# Altium schematic sheet hybris.SchDoc
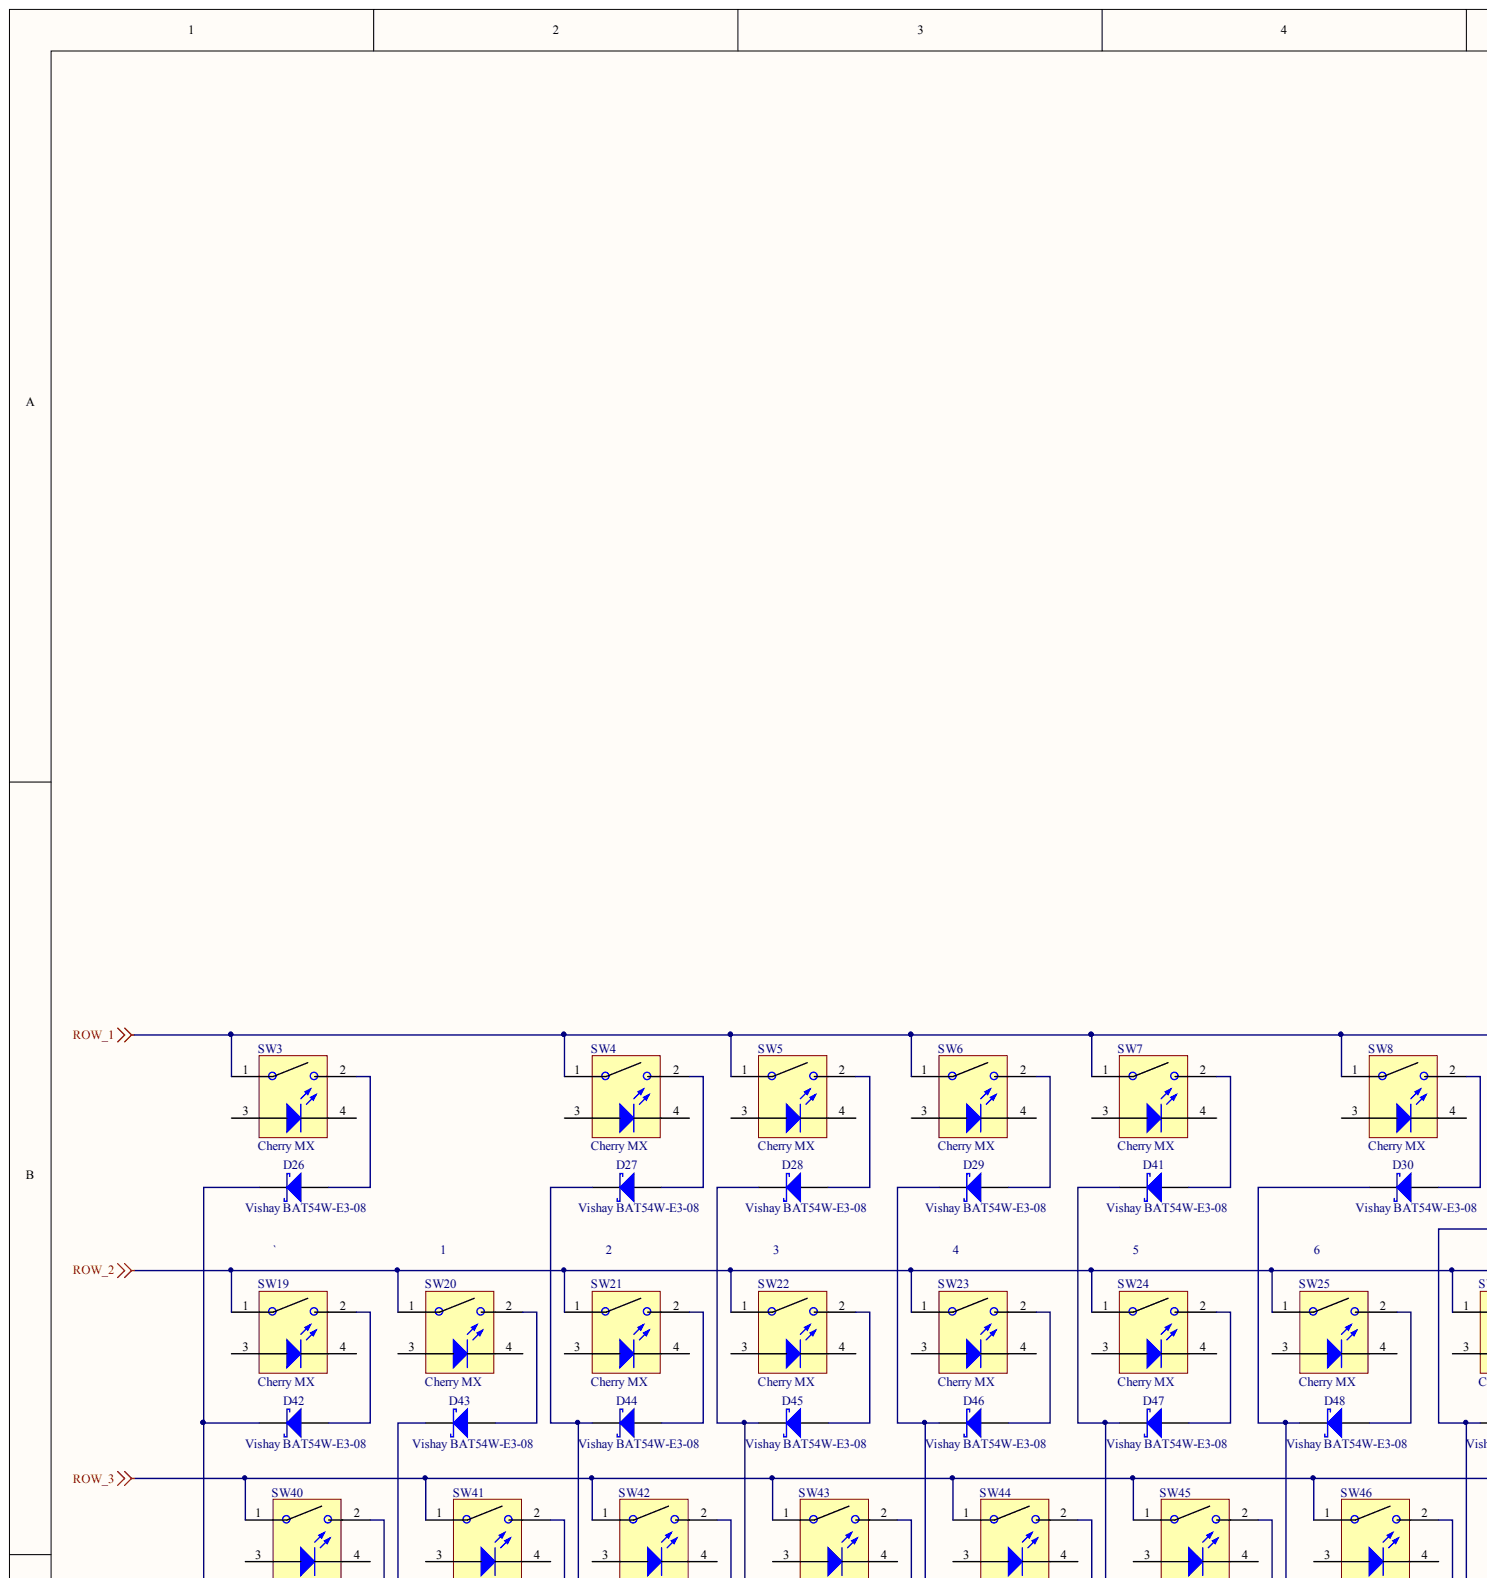
[diagram p0: hybris.SchDoc, top left]
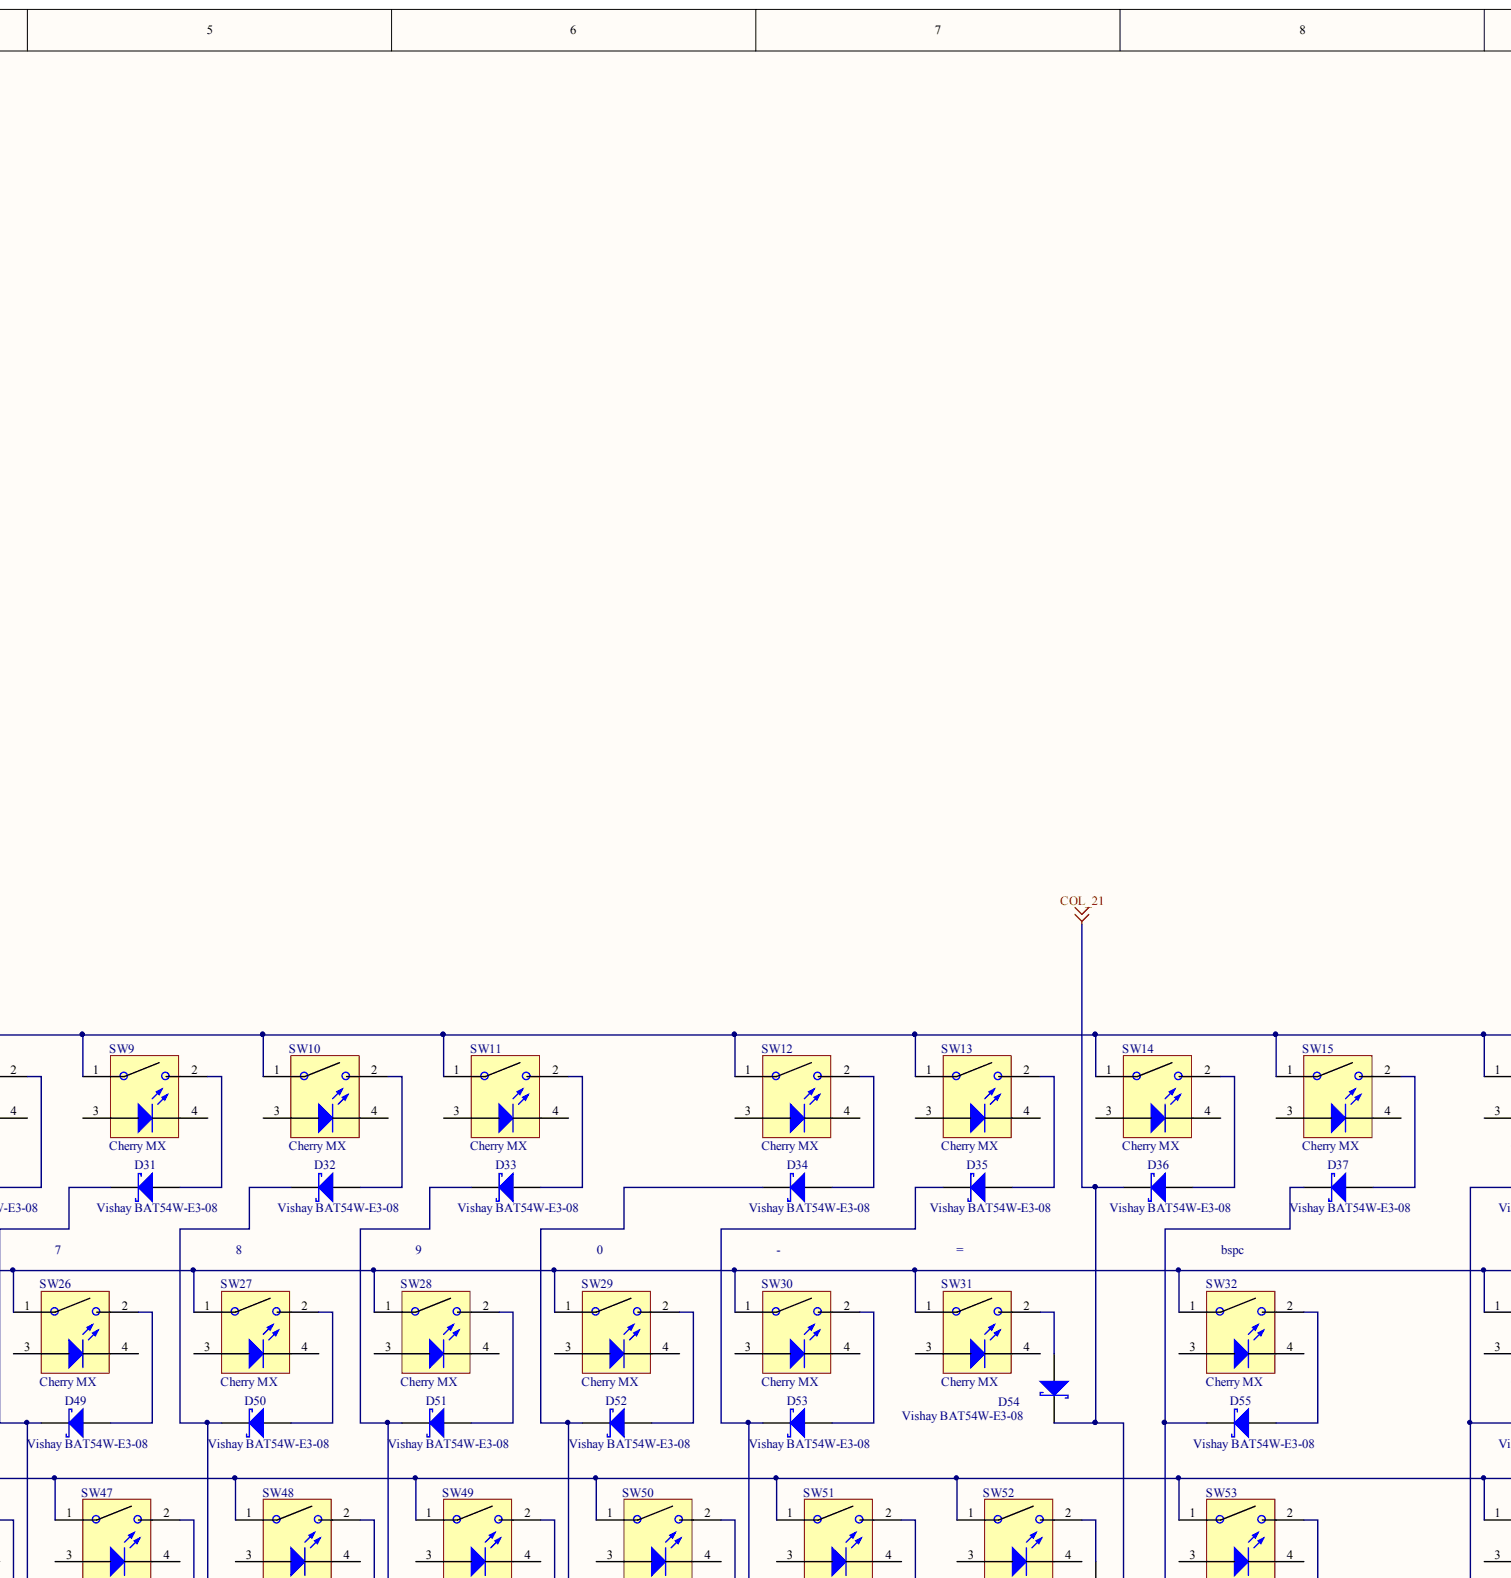
[diagram p1: hybris.SchDoc, top centre]
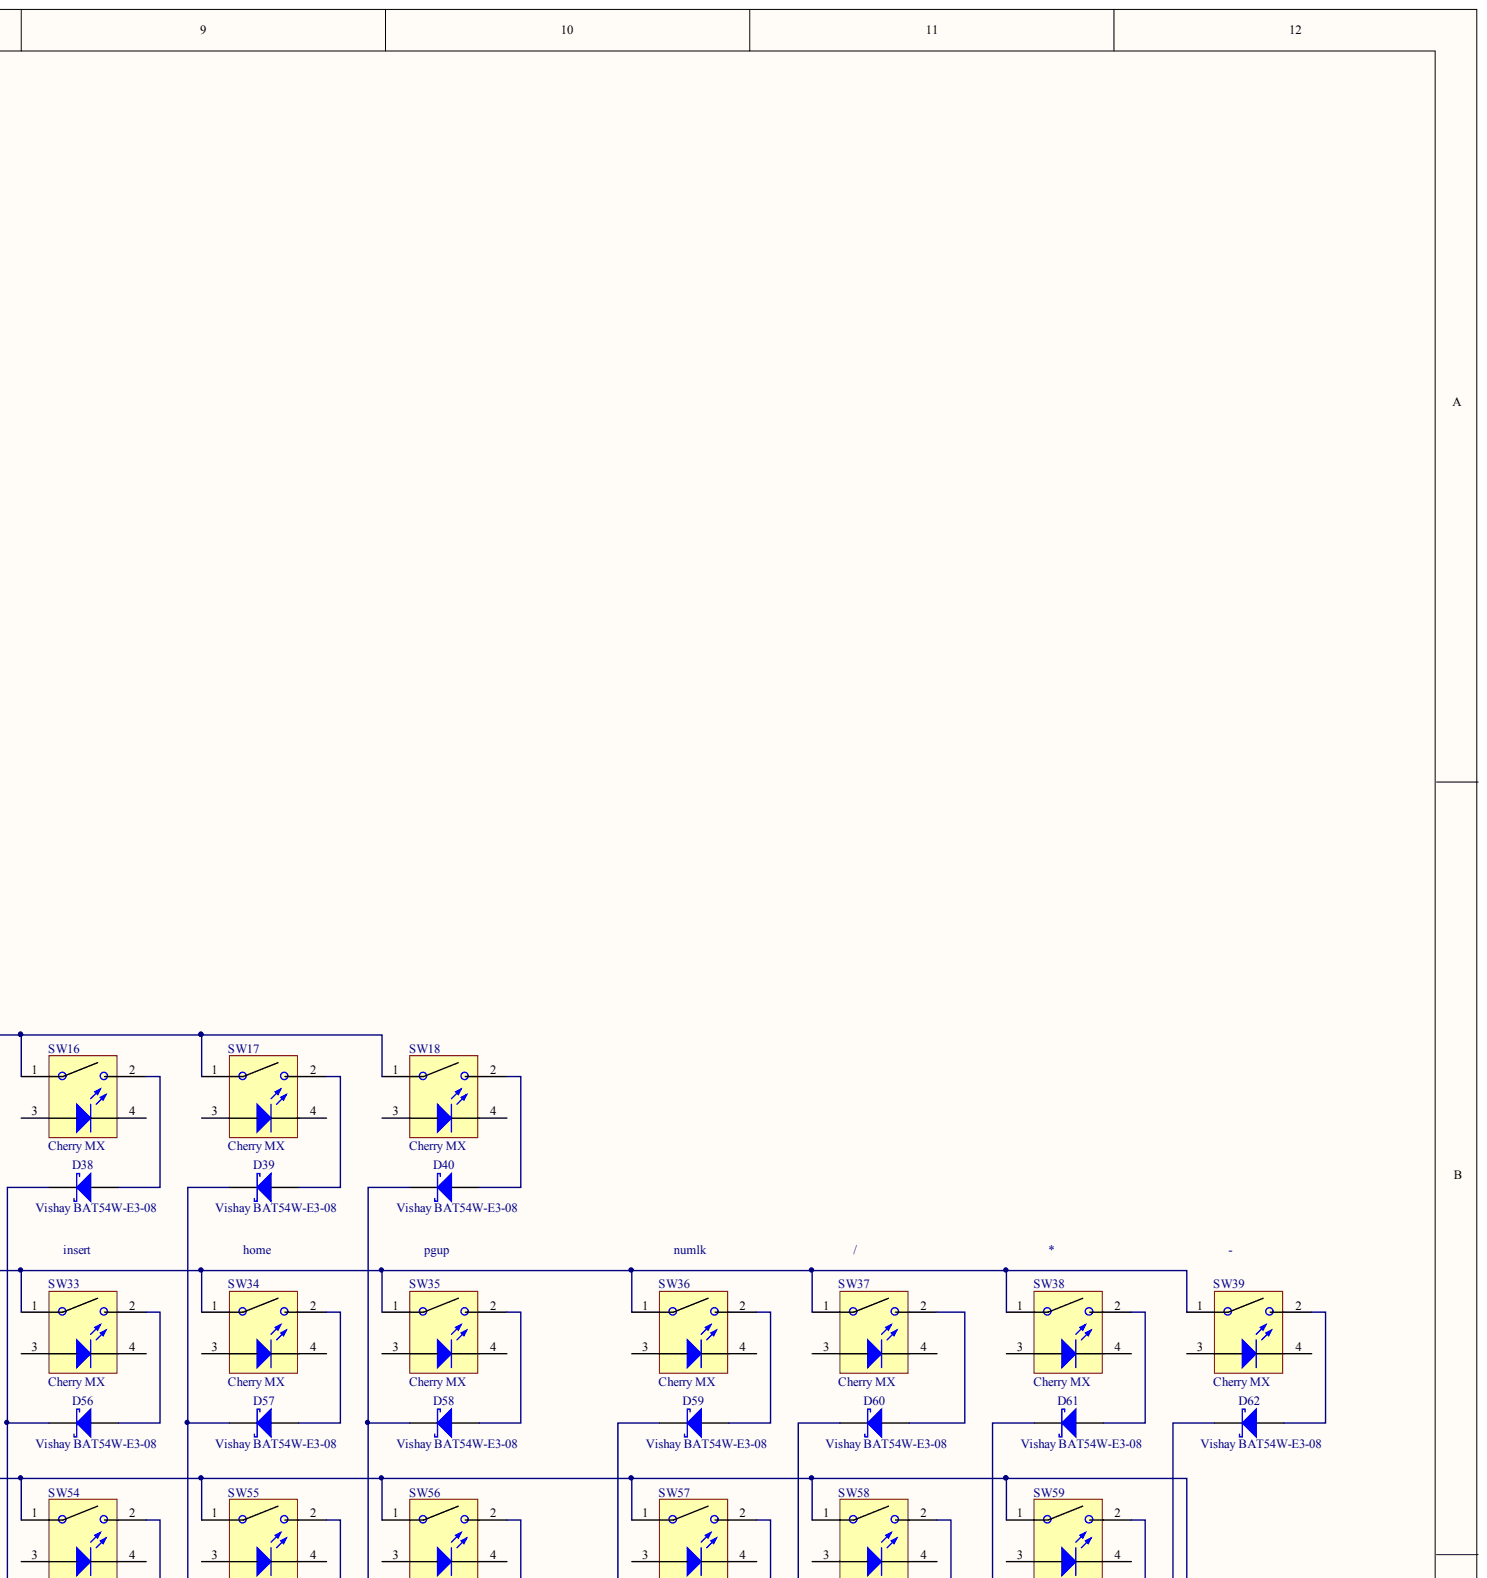
[diagram p2: hybris.SchDoc, top right]
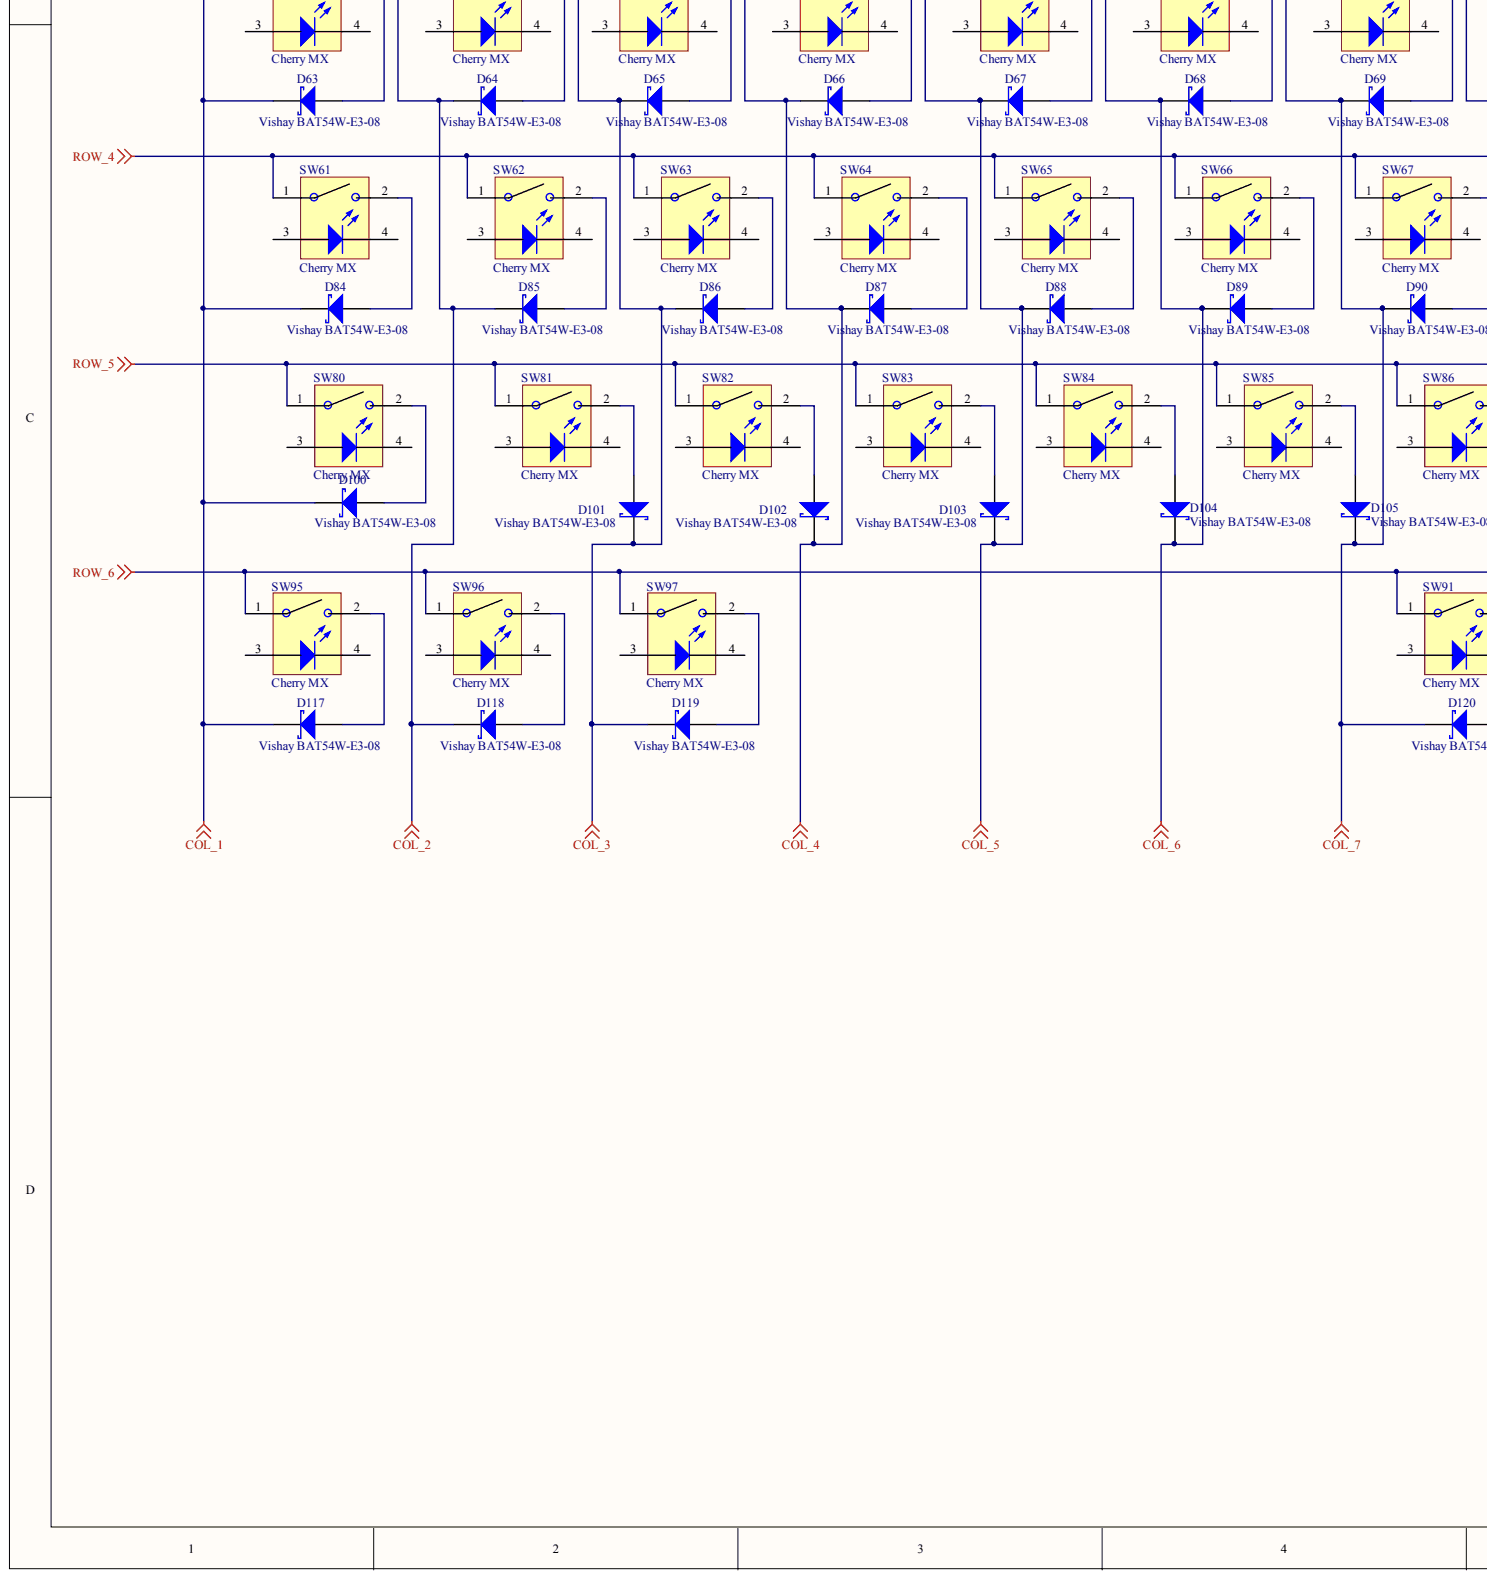
[diagram p3: hybris.SchDoc, bottom left]
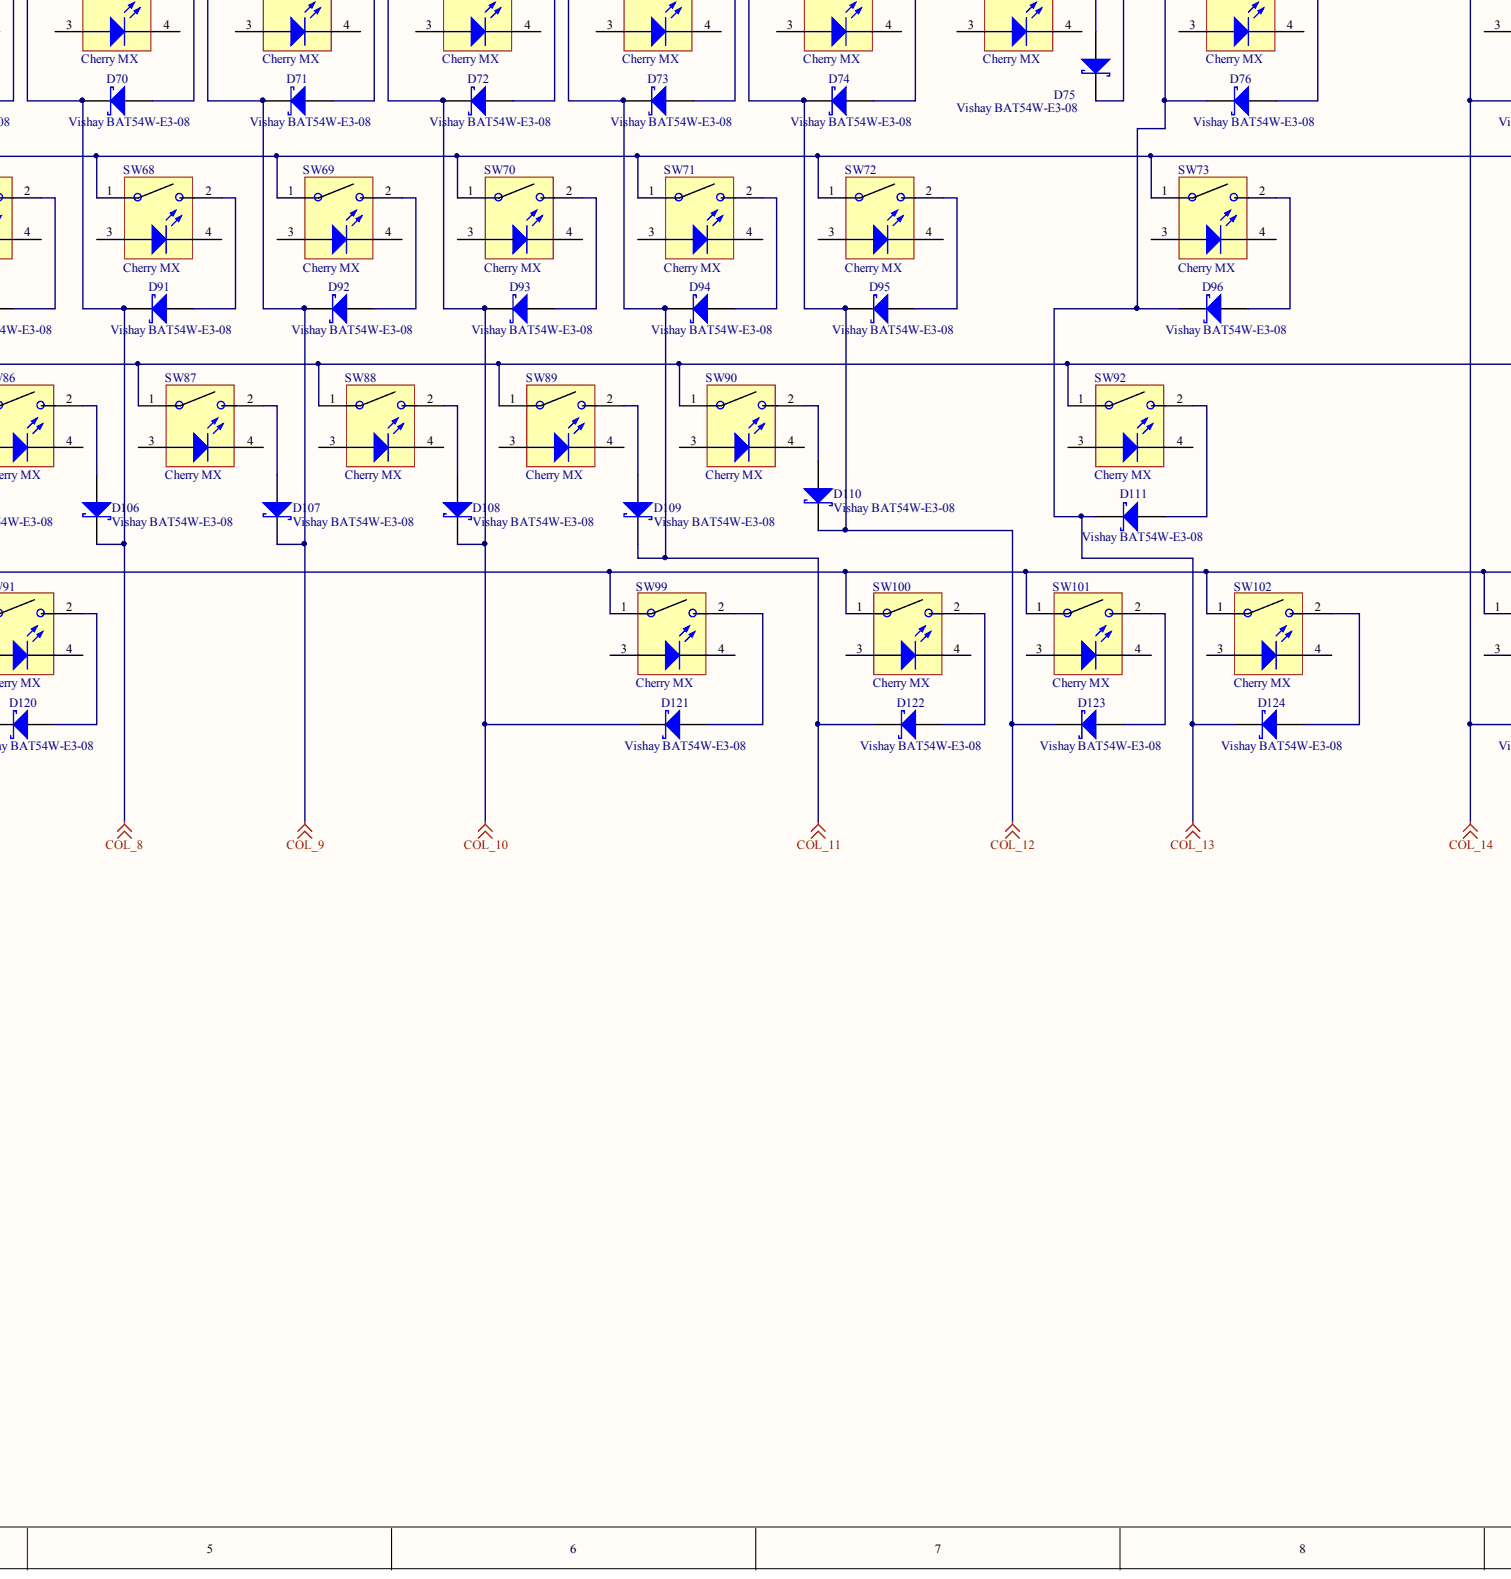
[diagram p4: hybris.SchDoc, bottom centre]
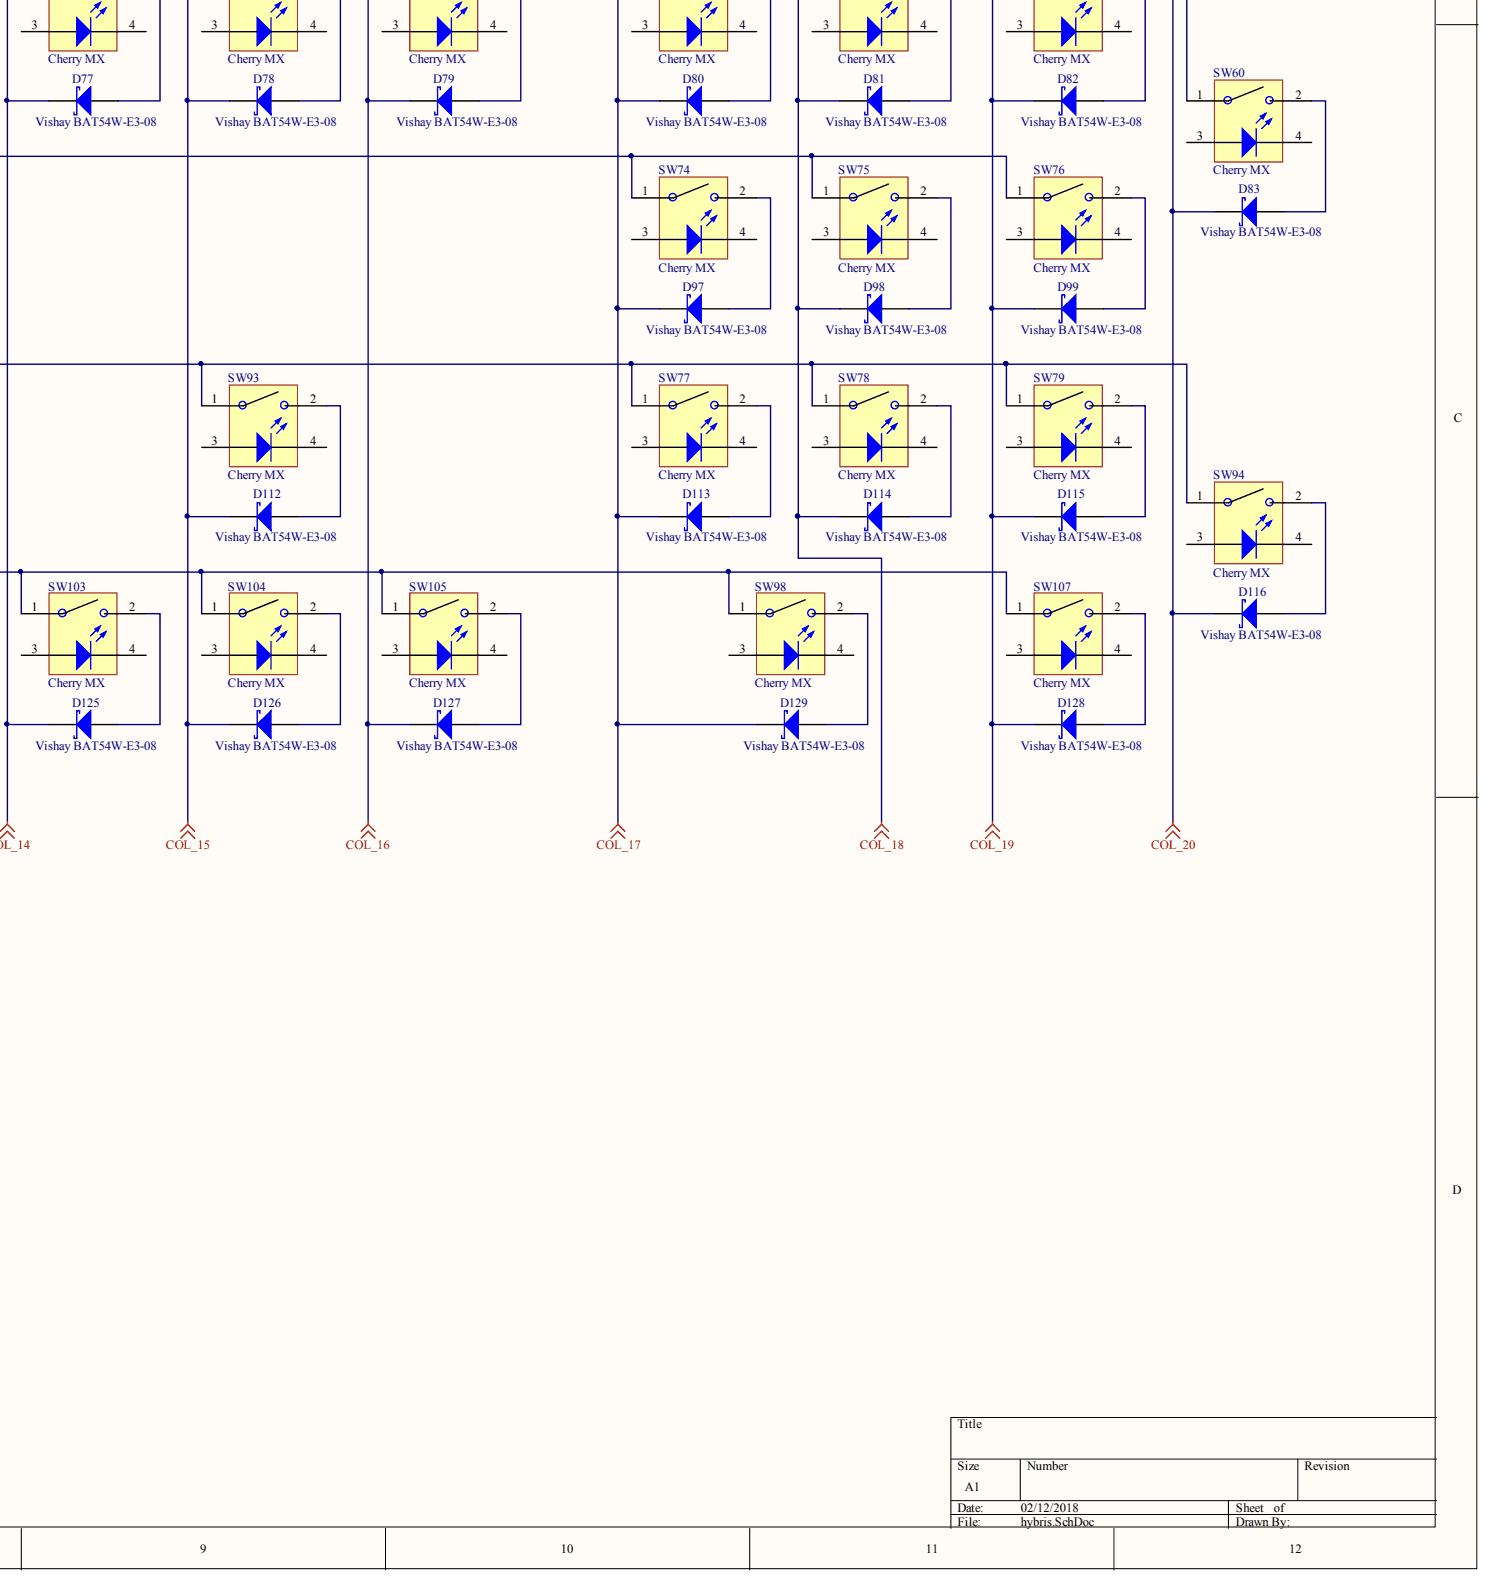
[diagram p5: hybris.SchDoc, bottom right]

## Parts
D26  Vishay BAT54W-E3-08  [PCB-12559945-1]
D27  Vishay BAT54W-E3-08  [PCB-12559945-1]
D28  Vishay BAT54W-E3-08  [PCB-12559945-1]
D29  Vishay BAT54W-E3-08  [PCB-12559945-1]
D30  Vishay BAT54W-E3-08  [PCB-12559945-1]
D31  Vishay BAT54W-E3-08  [PCB-12559945-1]
D32  Vishay BAT54W-E3-08  [PCB-12559945-1]
D33  Vishay BAT54W-E3-08  [PCB-12559945-1]
D34  Vishay BAT54W-E3-08  [PCB-12559945-1]
D35  Vishay BAT54W-E3-08  [PCB-12559945-1]
D36  Vishay BAT54W-E3-08  [PCB-12559945-1]
D37  Vishay BAT54W-E3-08  [PCB-12559945-1]
D38  Vishay BAT54W-E3-08  [PCB-12559945-1]
D39  Vishay BAT54W-E3-08  [PCB-12559945-1]
D40  Vishay BAT54W-E3-08  [PCB-12559945-1]
D41  Vishay BAT54W-E3-08  [PCB-12559945-1]
D42  Vishay BAT54W-E3-08  [PCB-12559945-1]
D43  Vishay BAT54W-E3-08  [PCB-12559945-1]
D44  Vishay BAT54W-E3-08  [PCB-12559945-1]
D45  Vishay BAT54W-E3-08  [PCB-12559945-1]
D46  Vishay BAT54W-E3-08  [PCB-12559945-1]
D47  Vishay BAT54W-E3-08  [PCB-12559945-1]
D48  Vishay BAT54W-E3-08  [PCB-12559945-1]
D49  Vishay BAT54W-E3-08  [PCB-12559945-1]
D50  Vishay BAT54W-E3-08  [PCB-12559945-1]
D51  Vishay BAT54W-E3-08  [PCB-12559945-1]
D52  Vishay BAT54W-E3-08  [PCB-12559945-1]
D53  Vishay BAT54W-E3-08  [PCB-12559945-1]
D54  Vishay BAT54W-E3-08  [PCB-12559945-1]
D55  Vishay BAT54W-E3-08  [PCB-12559945-1]
D56  Vishay BAT54W-E3-08  [PCB-12559945-1]
D57  Vishay BAT54W-E3-08  [PCB-12559945-1]
D58  Vishay BAT54W-E3-08  [PCB-12559945-1]
D59  Vishay BAT54W-E3-08  [PCB-12559945-1]
D60  Vishay BAT54W-E3-08  [PCB-12559945-1]
D61  Vishay BAT54W-E3-08  [PCB-12559945-1]
D62  Vishay BAT54W-E3-08  [PCB-12559945-1]
D63  Vishay BAT54W-E3-08  [PCB-12559945-1]
D64  Vishay BAT54W-E3-08  [PCB-12559945-1]
D65  Vishay BAT54W-E3-08  [PCB-12559945-1]
D66  Vishay BAT54W-E3-08  [PCB-12559945-1]
D67  Vishay BAT54W-E3-08  [PCB-12559945-1]
D68  Vishay BAT54W-E3-08  [PCB-12559945-1]
D69  Vishay BAT54W-E3-08  [PCB-12559945-1]
D70  Vishay BAT54W-E3-08  [PCB-12559945-1]
D71  Vishay BAT54W-E3-08  [PCB-12559945-1]
D72  Vishay BAT54W-E3-08  [PCB-12559945-1]
D73  Vishay BAT54W-E3-08  [PCB-12559945-1]
D74  Vishay BAT54W-E3-08  [PCB-12559945-1]
D75  Vishay BAT54W-E3-08  [PCB-12559945-1]
D76  Vishay BAT54W-E3-08  [PCB-12559945-1]
D77  Vishay BAT54W-E3-08  [PCB-12559945-1]
D78  Vishay BAT54W-E3-08  [PCB-12559945-1]
D79  Vishay BAT54W-E3-08  [PCB-12559945-1]
D80  Vishay BAT54W-E3-08  [PCB-12559945-1]
D81  Vishay BAT54W-E3-08  [PCB-12559945-1]
D82  Vishay BAT54W-E3-08  [PCB-12559945-1]
D83  Vishay BAT54W-E3-08  [PCB-12559945-1]
D84  Vishay BAT54W-E3-08  [PCB-12559945-1]
D85  Vishay BAT54W-E3-08  [PCB-12559945-1]
D86  Vishay BAT54W-E3-08  [PCB-12559945-1]
D87  Vishay BAT54W-E3-08  [PCB-12559945-1]
D88  Vishay BAT54W-E3-08  [PCB-12559945-1]
D89  Vishay BAT54W-E3-08  [PCB-12559945-1]
D90  Vishay BAT54W-E3-08  [PCB-12559945-1]
D91  Vishay BAT54W-E3-08  [PCB-12559945-1]
D92  Vishay BAT54W-E3-08  [PCB-12559945-1]
D93  Vishay BAT54W-E3-08  [PCB-12559945-1]
D94  Vishay BAT54W-E3-08  [PCB-12559945-1]
D95  Vishay BAT54W-E3-08  [PCB-12559945-1]
D96  Vishay BAT54W-E3-08  [PCB-12559945-1]
D97  Vishay BAT54W-E3-08  [PCB-12559945-1]
D98  Vishay BAT54W-E3-08  [PCB-12559945-1]
D99  Vishay BAT54W-E3-08  [PCB-12559945-1]
D100  Vishay BAT54W-E3-08  [PCB-12559945-1]
D101  Vishay BAT54W-E3-08  [PCB-12559945-1]
D102  Vishay BAT54W-E3-08  [PCB-12559945-1]
D103  Vishay BAT54W-E3-08  [PCB-12559945-1]
D104  Vishay BAT54W-E3-08  [PCB-12559945-1]
D105  Vishay BAT54W-E3-08  [PCB-12559945-1]
D106  Vishay BAT54W-E3-08  [PCB-12559945-1]
D107  Vishay BAT54W-E3-08  [PCB-12559945-1]
D108  Vishay BAT54W-E3-08  [PCB-12559945-1]
D109  Vishay BAT54W-E3-08  [PCB-12559945-1]
D110  Vishay BAT54W-E3-08  [PCB-12559945-1]
D111  Vishay BAT54W-E3-08  [PCB-12559945-1]
D112  Vishay BAT54W-E3-08  [PCB-12559945-1]
D113  Vishay BAT54W-E3-08  [PCB-12559945-1]
D114  Vishay BAT54W-E3-08  [PCB-12559945-1]
D115  Vishay BAT54W-E3-08  [PCB-12559945-1]
D116  Vishay BAT54W-E3-08  [PCB-12559945-1]
D117  Vishay BAT54W-E3-08  [PCB-12559945-1]
D118  Vishay BAT54W-E3-08  [PCB-12559945-1]
D119  Vishay BAT54W-E3-08  [PCB-12559945-1]
D120  Vishay BAT54W-E3-08  [PCB-12559945-1]
D121  Vishay BAT54W-E3-08  [PCB-12559945-1]
D122  Vishay BAT54W-E3-08  [PCB-12559945-1]
D123  Vishay BAT54W-E3-08  [PCB-12559945-1]
D124  Vishay BAT54W-E3-08  [PCB-12559945-1]
D125  Vishay BAT54W-E3-08  [PCB-12559945-1]
D126  Vishay BAT54W-E3-08  [PCB-12559945-1]
D127  Vishay BAT54W-E3-08  [PCB-12559945-1]
D128  Vishay BAT54W-E3-08  [PCB-12559945-1]
D129  Vishay BAT54W-E3-08  [PCB-12559945-1]
SW3  Cherry MX  [PCB-2657ggtsppkz9ec130bf-1]
SW4  Cherry MX  [PCB-2657ggtsppkz9ec130bf-1]
SW5  Cherry MX  [PCB-2657ggtsppkz9ec130bf-1]
SW6  Cherry MX  [PCB-2657ggtsppkz9ec130bf-1]
SW7  Cherry MX  [PCB-2657ggtsppkz9ec130bf-1]
SW8  Cherry MX  [PCB-2657ggtsppkz9ec130bf-1]
SW9  Cherry MX  [PCB-2657ggtsppkz9ec130bf-1]
SW10  Cherry MX  [PCB-2657ggtsppkz9ec130bf-1]
SW11  Cherry MX  [PCB-2657ggtsppkz9ec130bf-1]
SW12  Cherry MX  [PCB-2657ggtsppkz9ec130bf-1]
SW13  Cherry MX  [PCB-2657ggtsppkz9ec130bf-1]
SW14  Cherry MX  [PCB-2657ggtsppkz9ec130bf-1]
SW15  Cherry MX  [PCB-2657ggtsppkz9ec130bf-1]
SW16  Cherry MX  [PCB-2657ggtsppkz9ec130bf-1]
SW17  Cherry MX  [PCB-2657ggtsppkz9ec130bf-1]
SW18  Cherry MX  [PCB-2657ggtsppkz9ec130bf-1]
SW19  Cherry MX  [PCB-2657ggtsppkz9ec130bf-1]
SW20  Cherry MX  [PCB-2657ggtsppkz9ec130bf-1]
SW21  Cherry MX  [PCB-2657ggtsppkz9ec130bf-1]
SW22  Cherry MX  [PCB-2657ggtsppkz9ec130bf-1]
SW23  Cherry MX  [PCB-2657ggtsppkz9ec130bf-1]
SW24  Cherry MX  [PCB-2657ggtsppkz9ec130bf-1]
SW25  Cherry MX  [PCB-2657ggtsppkz9ec130bf-1]
SW26  Cherry MX  [PCB-2657ggtsppkz9ec130bf-1]
SW27  Cherry MX  [PCB-2657ggtsppkz9ec130bf-1]
SW28  Cherry MX  [PCB-2657ggtsppkz9ec130bf-1]
SW29  Cherry MX  [PCB-2657ggtsppkz9ec130bf-1]
SW30  Cherry MX  [PCB-2657ggtsppkz9ec130bf-1]
SW31  Cherry MX  [PCB-2657ggtsppkz9ec130bf-1]
SW32  Cherry MX  [PCB-m5qvng1dkbx2pn4yk235-1]
SW33  Cherry MX  [PCB-2657ggtsppkz9ec130bf-1]
SW34  Cherry MX  [PCB-2657ggtsppkz9ec130bf-1]
SW35  Cherry MX  [PCB-2657ggtsppkz9ec130bf-1]
SW36  Cherry MX  [PCB-2657ggtsppkz9ec130bf-1]
SW37  Cherry MX  [PCB-2657ggtsppkz9ec130bf-1]
SW38  Cherry MX  [PCB-2657ggtsppkz9ec130bf-1]
SW39  Cherry MX  [PCB-2657ggtsppkz9ec130bf-1]
SW40  Cherry MX  [PCB-2657ggtsppkz9ec130bf-1]
SW41  Cherry MX  [PCB-2657ggtsppkz9ec130bf-1]
SW42  Cherry MX  [PCB-2657ggtsppkz9ec130bf-1]
SW43  Cherry MX  [PCB-2657ggtsppkz9ec130bf-1]
SW44  Cherry MX  [PCB-2657ggtsppkz9ec130bf-1]
SW45  Cherry MX  [PCB-2657ggtsppkz9ec130bf-1]
SW46  Cherry MX  [PCB-2657ggtsppkz9ec130bf-1]
SW47  Cherry MX  [PCB-2657ggtsppkz9ec130bf-1]
SW48  Cherry MX  [PCB-2657ggtsppkz9ec130bf-1]
SW49  Cherry MX  [PCB-2657ggtsppkz9ec130bf-1]
SW50  Cherry MX  [PCB-2657ggtsppkz9ec130bf-1]
SW51  Cherry MX  [PCB-2657ggtsppkz9ec130bf-1]
SW52  Cherry MX  [PCB-2657ggtsppkz9ec130bf-1]
SW53  Cherry MX  [PCB-2657ggtsppkz9ec130bf-1]
SW54  Cherry MX  [PCB-2657ggtsppkz9ec130bf-1]
SW55  Cherry MX  [PCB-2657ggtsppkz9ec130bf-1]
SW56  Cherry MX  [PCB-2657ggtsppkz9ec130bf-1]
SW57  Cherry MX  [PCB-2657ggtsppkz9ec130bf-1]
SW58  Cherry MX  [PCB-2657ggtsppkz9ec130bf-1]
SW59  Cherry MX  [PCB-2657ggtsppkz9ec130bf-1]
SW60  Cherry MX  [PCB-m5qvng1dkbx2pn4yk235-1]
SW61  Cherry MX  [PCB-2657ggtsppkz9ec130bf-1]
SW62  Cherry MX  [PCB-2657ggtsppkz9ec130bf-1]
SW63  Cherry MX  [PCB-2657ggtsppkz9ec130bf-1]
SW64  Cherry MX  [PCB-2657ggtsppkz9ec130bf-1]
SW65  Cherry MX  [PCB-2657ggtsppkz9ec130bf-1]
SW66  Cherry MX  [PCB-2657ggtsppkz9ec130bf-1]
SW67  Cherry MX  [PCB-2657ggtsppkz9ec130bf-1]
SW68  Cherry MX  [PCB-2657ggtsppkz9ec130bf-1]
SW69  Cherry MX  [PCB-2657ggtsppkz9ec130bf-1]
SW70  Cherry MX  [PCB-2657ggtsppkz9ec130bf-1]
SW71  Cherry MX  [PCB-2657ggtsppkz9ec130bf-1]
SW72  Cherry MX  [PCB-2657ggtsppkz9ec130bf-1]
SW73  Cherry MX  [PCB-m5qvng1dkbx2pn4yk235-1]
SW74  Cherry MX  [PCB-2657ggtsppkz9ec130bf-1]
SW75  Cherry MX  [PCB-2657ggtsppkz9ec130bf-1]
SW76  Cherry MX  [PCB-2657ggtsppkz9ec130bf-1]
SW77  Cherry MX  [PCB-2657ggtsppkz9ec130bf-1]
SW78  Cherry MX  [PCB-2657ggtsppkz9ec130bf-1]
SW79  Cherry MX  [PCB-2657ggtsppkz9ec130bf-1]
SW80  Cherry MX  [PCB-m5qvng1dkbx2pn4yk235-1]
SW81  Cherry MX  [PCB-2657ggtsppkz9ec130bf-1]
SW82  Cherry MX  [PCB-2657ggtsppkz9ec130bf-1]
SW83  Cherry MX  [PCB-2657ggtsppkz9ec130bf-1]
SW84  Cherry MX  [PCB-2657ggtsppkz9ec130bf-1]
SW85  Cherry MX  [PCB-2657ggtsppkz9ec130bf-1]
SW86  Cherry MX  [PCB-2657ggtsppkz9ec130bf-1]
SW87  Cherry MX  [PCB-2657ggtsppkz9ec130bf-1]
SW88  Cherry MX  [PCB-2657ggtsppkz9ec130bf-1]
SW89  Cherry MX  [PCB-2657ggtsppkz9ec130bf-1]
SW90  Cherry MX  [PCB-2657ggtsppkz9ec130bf-1]
SW91  Cherry MX  [PCB-o8c15rp4mcoac7l9pxsm-1]
SW92  Cherry MX  [PCB-m5qvng1dkbx2pn4yk235-1]
SW93  Cherry MX  [PCB-2657ggtsppkz9ec130bf-1]
SW94  Cherry MX  [PCB-m5qvng1dkbx2pn4yk235-1]
SW95  Cherry MX  [PCB-2657ggtsppkz9ec130bf-1]
SW96  Cherry MX  [PCB-2657ggtsppkz9ec130bf-1]
SW97  Cherry MX  [PCB-2657ggtsppkz9ec130bf-1]
SW98  Cherry MX  [PCB-m5qvng1dkbx2pn4yk235-1]
SW99  Cherry MX  [PCB-2657ggtsppkz9ec130bf-1]
SW100  Cherry MX  [PCB-2657ggtsppkz9ec130bf-1]
SW101  Cherry MX  [PCB-2657ggtsppkz9ec130bf-1]
SW102  Cherry MX  [PCB-2657ggtsppkz9ec130bf-1]
SW103  Cherry MX  [PCB-2657ggtsppkz9ec130bf-1]
SW104  Cherry MX  [PCB-2657ggtsppkz9ec130bf-1]
SW105  Cherry MX  [PCB-2657ggtsppkz9ec130bf-1]
SW107  Cherry MX  [PCB-2657ggtsppkz9ec130bf-1]

## Nets
COL_1: D26.K D42.K D63.K D84.K D100.K D117.K
COL_2: D43.K D64.K D85.K D118.K
COL_3: D27.K D44.K D65.K D86.K D101.K D119.K
COL_4: D28.K D45.K D66.K D87.K D102.K
COL_5: D29.K D46.K D67.K D88.K D103.K
COL_6: D41.K D47.K D68.K D89.K D104.K
COL_7: D30.K D48.K D69.K D90.K D105.K D120.K
COL_8: D31.K D49.K D70.K D91.K D106.K
COL_9: D32.K D50.K D71.K D92.K D107.K
COL_10: D33.K D51.K D72.K D93.K D108.K D121.K
COL_11: D34.K D52.K D73.K D94.K D109.K D122.K
COL_12: D35.K D53.K D74.K D95.K D110.K D123.K
COL_13: D37.K D55.K D76.K D96.K D111.K D124.K
COL_14: D38.K D56.K D77.K D125.K
COL_15: D39.K D57.K D78.K D112.K D126.K
COL_16: D40.K D58.K D79.K D127.K
COL_17: D59.K D80.K D97.K D113.K D129.K
COL_18: D60.K D81.K D98.K D114.K
COL_19: D61.K D82.K D99.K D115.K D128.K
COL_20: D62.K D83.K D116.K
COL_21: D36.K D54.K D75.K
ROW_1: SW3.1 SW4.1 SW5.1 SW6.1 SW7.1 SW8.1 SW9.1 SW10.1 SW11.1 SW12.1 SW13.1 SW14.1 SW15.1 SW16.1 SW17.1 SW18.1
ROW_2: SW19.1 SW20.1 SW21.1 SW22.1 SW23.1 SW24.1 SW25.1 SW26.1 SW27.1 SW28.1 SW29.1 SW30.1 SW31.1 SW32.1 SW33.1 SW34.1 SW35.1 SW36.1 SW37.1 SW38.1 SW39.1
ROW_3: SW40.1 SW41.1 SW42.1 SW43.1 SW44.1 SW45.1 SW46.1 SW47.1 SW48.1 SW49.1 SW50.1 SW51.1 SW52.1 SW53.1 SW54.1 SW55.1 SW56.1 SW57.1 SW58.1 SW59.1 SW60.1
ROW_4: SW61.1 SW62.1 SW63.1 SW64.1 SW65.1 SW66.1 SW67.1 SW68.1 SW69.1 SW70.1 SW71.1 SW72.1 SW73.1 SW74.1 SW75.1 SW76.1
ROW_5: SW77.1 SW78.1 SW79.1 SW80.1 SW81.1 SW82.1 SW83.1 SW84.1 SW85.1 SW86.1 SW87.1 SW88.1 SW89.1 SW90.1 SW92.1 SW93.1 SW94.1
ROW_6: SW91.1 SW95.1 SW96.1 SW97.1 SW98.1 SW99.1 SW100.1 SW101.1 SW102.1 SW103.1 SW104.1 SW105.1 SW107.1
NetD26_A: D26.A SW3.2
NetD27_A: D27.A SW4.2
NetD28_A: D28.A SW5.2
NetD29_A: D29.A SW6.2
NetD30_A: D30.A SW8.2
NetD31_A: D31.A SW9.2
NetD32_A: D32.A SW10.2
NetD33_A: D33.A SW11.2
NetD34_A: D34.A SW12.2
NetD35_A: D35.A SW13.2
NetD36_A: D36.A SW14.2
NetD37_A: D37.A SW15.2
NetD38_A: D38.A SW16.2
NetD39_A: D39.A SW17.2
NetD40_A: D40.A SW18.2
NetD41_A: D41.A SW7.2
NetD42_A: D42.A SW19.2
NetD43_A: D43.A SW20.2
NetD44_A: D44.A SW21.2
NetD45_A: D45.A SW22.2
NetD46_A: D46.A SW23.2
NetD47_A: D47.A SW24.2
NetD48_A: D48.A SW25.2
NetD49_A: D49.A SW26.2
NetD50_A: D50.A SW27.2
NetD51_A: D51.A SW28.2
NetD52_A: D52.A SW29.2
NetD53_A: D53.A SW30.2
NetD54_A: D54.A SW31.2
NetD55_A: D55.A SW32.2
NetD56_A: D56.A SW33.2
NetD57_A: D57.A SW34.2
NetD58_A: D58.A SW35.2
NetD59_A: D59.A SW36.2
NetD60_A: D60.A SW37.2
NetD61_A: D61.A SW38.2
NetD62_A: D62.A SW39.2
NetD63_A: D63.A SW40.2
NetD64_A: D64.A SW41.2
NetD65_A: D65.A SW42.2
NetD66_A: D66.A SW43.2
NetD67_A: D67.A SW44.2
NetD68_A: D68.A SW45.2
NetD69_A: D69.A SW46.2
NetD70_A: D70.A SW47.2
NetD71_A: D71.A SW48.2
NetD72_A: D72.A SW49.2
NetD73_A: D73.A SW50.2
NetD74_A: D74.A SW51.2
NetD75_A: D75.A SW52.2
NetD76_A: D76.A SW53.2
NetD77_A: D77.A SW54.2
NetD78_A: D78.A SW55.2
NetD79_A: D79.A SW56.2
NetD80_A: D80.A SW57.2
NetD81_A: D81.A SW58.2
NetD82_A: D82.A SW59.2
NetD83_A: D83.A SW60.2
NetD84_A: D84.A SW61.2
NetD85_A: D85.A SW62.2
NetD86_A: D86.A SW63.2
NetD87_A: D87.A SW64.2
NetD88_A: D88.A SW65.2
NetD89_A: D89.A SW66.2
NetD90_A: D90.A SW67.2
NetD91_A: D91.A SW68.2
NetD92_A: D92.A SW69.2
NetD93_A: D93.A SW70.2
NetD94_A: D94.A SW71.2
NetD95_A: D95.A SW72.2
NetD96_A: D96.A SW73.2
NetD97_A: D97.A SW74.2
NetD98_A: D98.A SW75.2
NetD99_A: D99.A SW76.2
NetD100_A: D100.A SW80.2
NetD101_A: D101.A SW81.2
NetD102_A: D102.A SW82.2
NetD103_A: D103.A SW83.2
NetD104_A: D104.A SW84.2
NetD105_A: D105.A SW85.2
NetD106_A: D106.A SW86.2
NetD107_A: D107.A SW87.2
NetD108_A: D108.A SW88.2
NetD109_A: D109.A SW89.2
NetD110_A: D110.A SW90.2
NetD111_A: D111.A SW92.2
NetD112_A: D112.A SW93.2
NetD113_A: D113.A SW77.2
NetD114_A: D114.A SW78.2
NetD115_A: D115.A SW79.2
NetD116_A: D116.A SW94.2
NetD117_A: D117.A SW95.2
NetD118_A: D118.A SW96.2
NetD119_A: D119.A SW97.2
NetD120_A: D120.A SW91.2
NetD121_A: D121.A SW99.2
NetD122_A: D122.A SW100.2
NetD123_A: D123.A SW101.2
NetD124_A: D124.A SW102.2
NetD125_A: D125.A SW103.2
NetD126_A: D126.A SW104.2
NetD127_A: D127.A SW105.2
NetD128_A: D128.A SW107.2
NetD129_A: D129.A SW98.2

Not connected: SW3 3 4; SW4 3 4; SW5 3 4; SW6 3 4; SW7 3 4; SW8 3 4; SW9 3 4; SW10 3 4; SW11 3 4; SW12 3 4; SW13 3 4; SW14 3 4; SW15 3 4; SW16 3 4; SW17 3 4; SW18 3 4; SW19 3 4; SW20 3 4; SW21 3 4; SW22 3 4; SW23 3 4; SW24 3 4; SW25 3 4; SW26 3 4; SW27 3 4; SW28 3 4; SW29 3 4; SW30 3 4; SW31 3 4; SW32 3 4; SW33 3 4; SW34 3 4; SW35 3 4; SW36 3 4; SW37 3 4; SW38 3 4; SW39 3 4; SW40 3 4; SW41 3 4; SW42 3 4; SW43 3 4; SW44 3 4; SW45 3 4; SW46 3 4; SW47 3 4; SW48 3 4; SW49 3 4; SW50 3 4; SW51 3 4; SW52 3 4; SW53 3 4; SW54 3 4; SW55 3 4; SW56 3 4; SW57 3 4; SW58 3 4; SW59 3 4; SW60 3 4; SW61 3 4; SW62 3 4; SW63 3 4; SW64 3 4; SW65 3 4; SW66 3 4; SW67 3 4; SW68 3 4; SW69 3 4; SW70 3 4; SW71 3 4; SW72 3 4; SW73 3 4; SW74 3 4; SW75 3 4; SW76 3 4; SW77 3 4; SW78 3 4; SW79 3 4; SW80 3 4; SW81 3 4; SW82 3 4; SW83 3 4; SW84 3 4; SW85 3 4; SW86 3 4; SW87 3 4; SW88 3 4; SW89 3 4; SW90 3 4; SW91 3 4; SW92 3 4; SW93 3 4; SW94 3 4; SW95 3 4; SW96 3 4; SW97 3 4; SW98 3 4; SW99 3 4; SW100 3 4; SW101 3 4; SW102 3 4; SW103 3 4; SW104 3 4; SW105 3 4; SW107 3 4

## Library data of the parts
D26-D129 = CMP-12559945-3: Diode Small Signal Schottky 30V 0.2A 2-Pin SOD-123 T/R; Component Kind: Standard; Library Name: CommunityVault; Number of Pins: 2; OctopartID: e6202ee47b2139e7; Octopart Url: https://octopart.com/bat54w-e3-08-vishay-30324839; RoHS: Compliant
SW3-SW31 SW33-SW59 SW61-SW72 SW74-SW79 SW81-SW90 SW93 SW95-SW97 SW99-SW105 SW107 = CMP-58e2950967738725-3: Brown Stem, Full Travel Mechanical Keyswitch Tactile Feel, 55cn No Diode, No Led Fixation Pins For Pcb Mounting; Component Kind: Standard; Library Name: CommunityVault; OctopartID: 58e2950967738725; Octopart URL: https://octopart.com/mx1a-g1nw-cherry-81861367
SW32 SW60 SW73 SW80 SW92 SW94 SW98 = CMP-bncz9abuh8hyi9un2l0j-2: Cherry MX footprint with a 2U PCB mount stabilizer; Component Kind: Standard; CustomComponentID: bncz9abuh8hyi9un2l0j; Library Name: CommunityVault
SW91 = CMP-bajezik8lkx34vwz65so-2: Cherry MX footprint with 6.25u PCB mount stabilizer; Component Kind: Standard; Library Name: CommunityVault
